# Altium schematic sheet MCU.SchDoc(MCU)
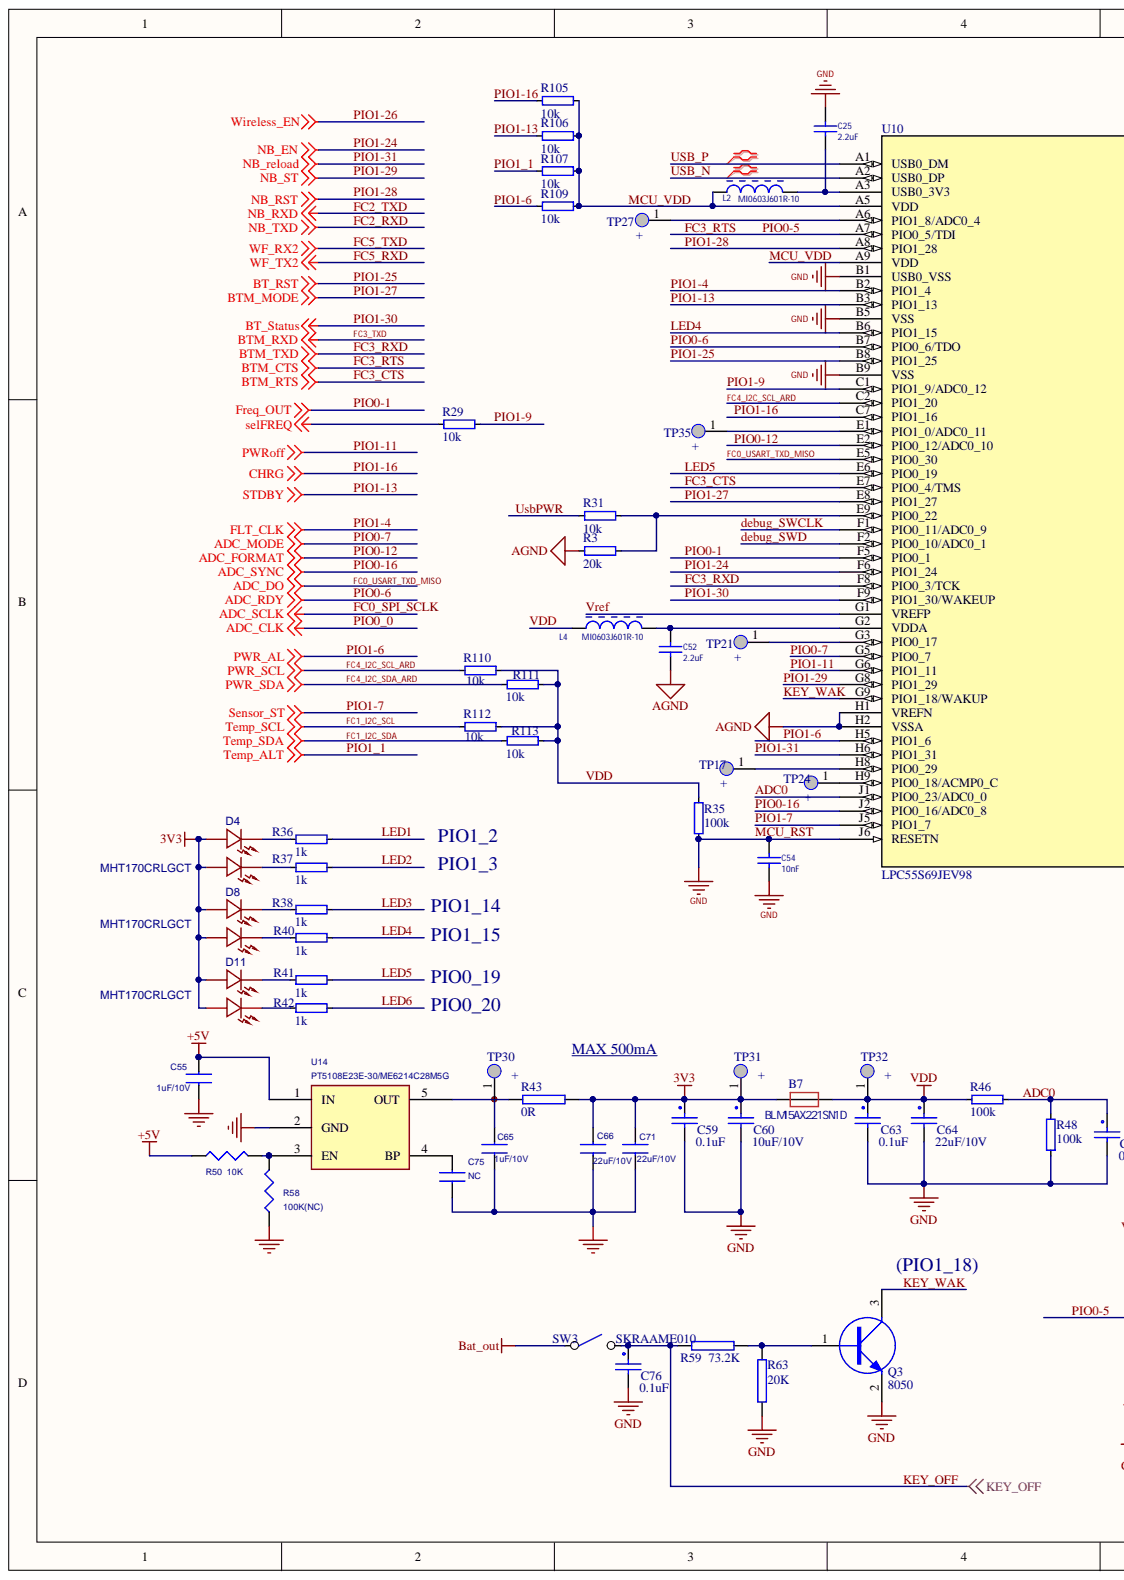
[diagram p0: MCU.SchDoc, left]
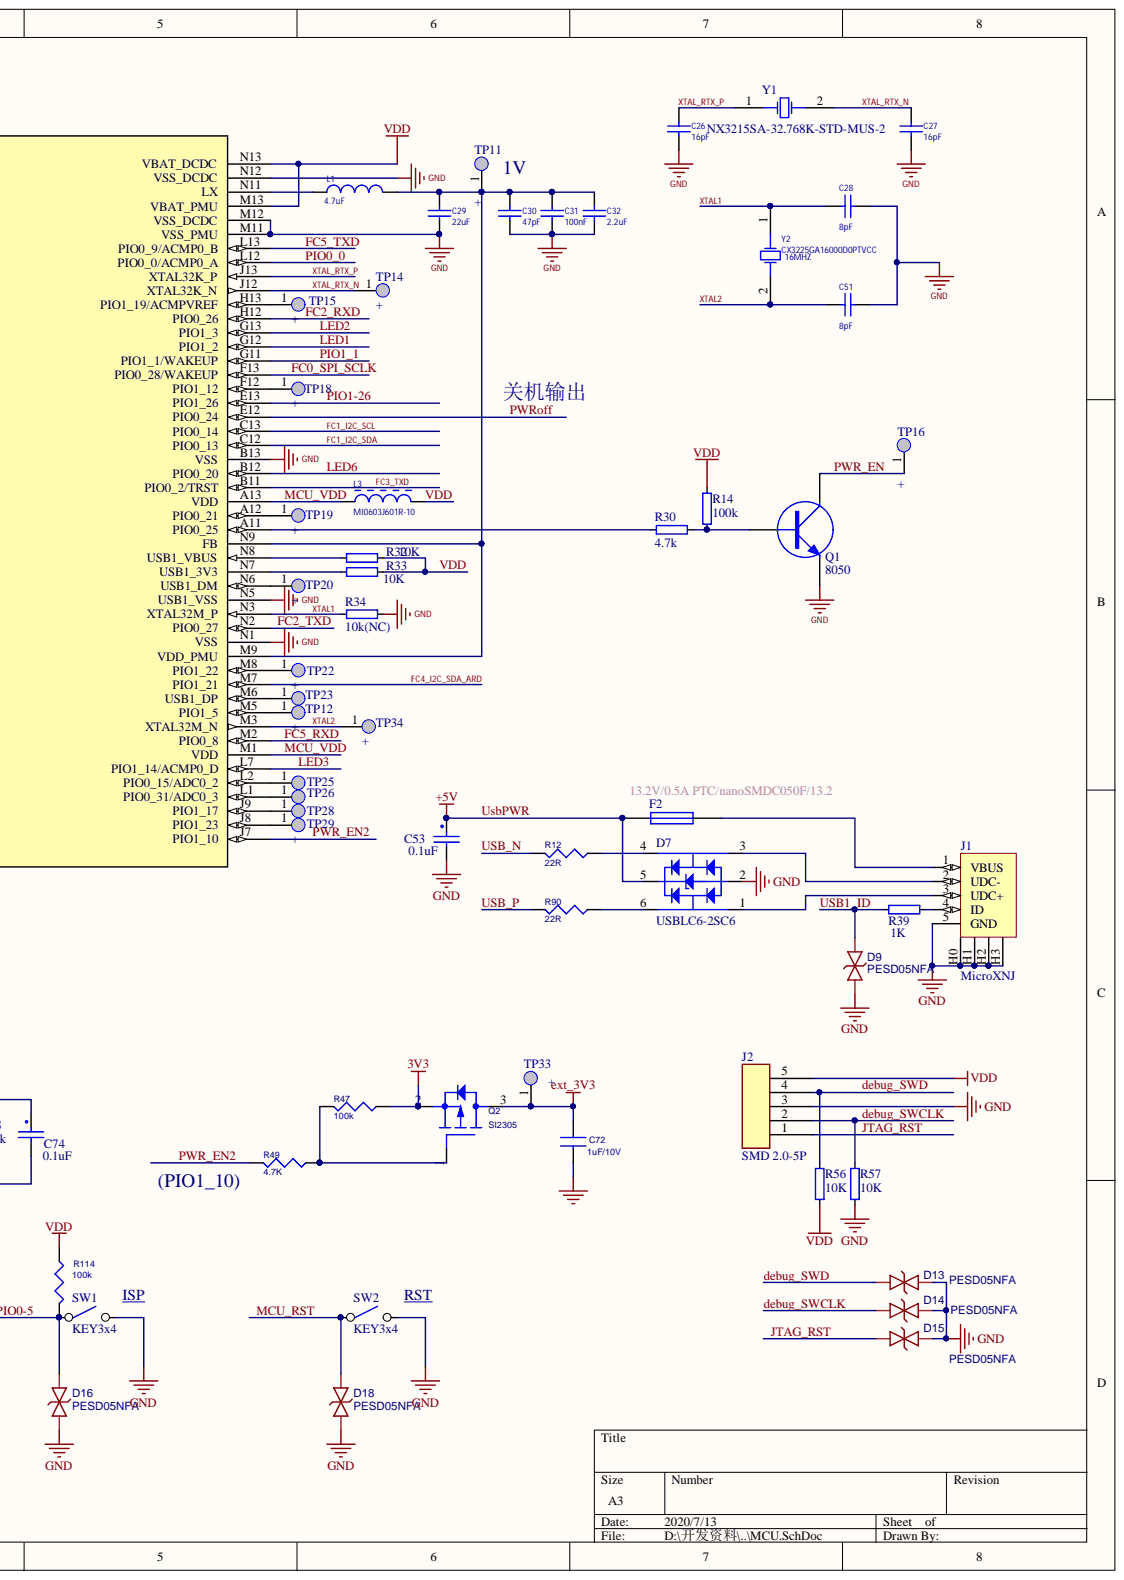
[diagram p1: MCU.SchDoc, right]

## Parts
B7  BLM15AX221SN1D  [B0402]
C25  value 2.2uF  [CAPC0402(1005)60_L]  (hidden comment: CAP 2.2uF 10V 0402(1005))
C26  value 16pF  [CAPC0402(1005)60_L]  (hidden comment: CAP 16pF 25V 0402(1005))
C27  value 16pF  [CAPC0402(1005)60_L]  (hidden comment: CAP 16pF 25V 0402(1005))
C28  value 8pF  [0402_L]  (hidden comment: GRM1555C1E8R0CA01D)
C29  value 22uF  [CAPC0805(2012)145_L]  (hidden comment: CAP 22uF 16V 0805(2012))
C30  value 47pF  [CAPC0603(1608)100_L]  (hidden comment: CAP 47pF 16V 0603(1608))
C31  value 100nF  [CAPC0603(1608)100_L]  (hidden comment: CAP 100nF 25V 0603(1608))
C32  value 2.2uF  [CAPC0402(1005)60_L]  (hidden comment: CAP 2.2uF 10V 0402(1005))
C51  value 8pF  [0402_L]  (hidden comment: GRM1555C1E8R0CA01D)
C52  value 2.2uF  [CAPC0402(1005)60_L]  (hidden comment: CAP 2.2uF 10V 0402(1005))
C53  0.1uF  [0402_C]
C54  value 10nF  [CAPC0603(1608)100_L]  (hidden comment: CAP 100nF 25V 0603(1608))
C55  1uF/10V  [0402_C]
C59  0.1uF  [0402_C]
C60  10uF/10V  [0603_C]
C63  0.1uF  [0402_C]
C64  22uF/10V  [0603_C]
C65  1uF/10V  [0402_C]
C66  22uF/10V  [0603_C]
C71  22uF/10V  [0603_C]
C72  1uF/10V  [0402_C]
C74  0.1uF  [0402_C]
C75  NC  [0402_C]
C76  0.1uF  [0402_C]
D4  MHT170CRLGCT  [LED2_0805]
D7  USBLC6-2SC6  [SOT23-6]
D8  MHT170CRLGCT  [LED2_0805]
D9  PESD05NFA  [D0402-BI]
D11  MHT170CRLGCT  [LED2_0805]
D13  PESD05NFA  [D0402-BI]
D14  PESD05NFA  [D0402-BI]
D15  PESD05NFA  [D0402-BI]
D16  PESD05NFA  [D0402-BI]
D18  PESD05NFA  [D0402-BI]
F2  13.2V/0.5A PTC/nanoSMDC050F/13.2  [F1206]
J1  MicroXNJ  [USB-005H]
J2  SMD 2.0-5P  [P2.0*5]
L1  value 4.7uF  [0805(2012)]  (hidden comment: MLZ2012M4R7HT000)
L2  MI0603J601R-10  [LIRD-MI0603J601R-10_V]
L3  MI0603J601R-10  [LIRD-MI0603J601R-10_V]
L4  MI0603J601R-10  [LIRD-MI0603J601R-10_V]
Q1  8050  [SOT-23(SOT-23-3)]
Q2  SI2305  [SOT23]
Q3  8050  [SOT-23(SOT-23-3)]
R3  20k  [0402]
R12  22R  [0402_R]
R14  100k  [0402]
R29  10k  [0402]
R30  4.7k  [0402]
R31  10k  [0402]
R32  10K  [0402]
R33  10K  [0402]
R34  10k(NC)  [0402]
R35  100k  [0402]
R36  1k  [0402]
R37  1k  [0402]
R38  1k  [0402]
R39  1K  [0402]
R40  1k  [0402]
R41  1k  [0402]
R42  1k  [0402]
R43  0R  [0402_R]
R46  100k  [0402]
R47  100k  [0402_R]
R48  100k  [0402]
R49  4.7K  [0402_R]
R50  10K  [0402_R]
R56  10K  [0402]
R57  10K  [0402]
R58  100K(NC)  [0402_R]
R59  73.2K  [0402_R]
R63  20K  [0402_R]
R90  22R  [0402_R]
R105  10k  [0402]
R106  10k  [0402]
R107  10k  [0402]
R109  10k  [0402]
R110  10k  [0402]
R111  10k  [0402]
R112  10k  [0402]
R113  10k  [0402]
R114  100k  [0402_R]
SW1  KEY3x4  [SMDKEY]
SW2  KEY3x4  [SMDKEY]
SW3  SKRAAME010  [SW-SMD_4P-L6.2-W6.2-P4.00-LS6.8]
TP11  +  [TP]
TP12  +  [TP]
TP14  +  [TP]
TP15  +  [TP]
TP16  +  [TP]
TP17  +  [TP]
TP18  +  [TP]
TP19  +  [TP]
TP20  +  [TP]
TP21  +  [TP]
TP22  +  [TP]
TP23  +  [TP]
TP24  +  [TP]
TP25  +  [TP]
TP26  +  [TP]
TP27  +  [TP]
TP28  +  [TP]
TP29  +  [TP]
TP30  +  [TP]
TP31  +  [TP]
TP32  +  [TP]
TP33  +  [TP]
TP34  +  [TP]
TP35  +  [TP]
U10  LPC55S69JEV98  [VFBGA98]
U14  PT5108E23E-30/ME6214C28M5G  [SOT23-5]
Y1  NX3215SA-32.768K-STD-MUS-2  [2-SMD 3.2x1.5mm]
Y2  CX3225GA16000D0PTVCC  value 16MHZ  [CX3225GA]

## Nets
3V3: B7.1 C59.1 C60.1 C66.1 C71.1 D4.3 D4.4 D8.3 D8.4 D11.3 D11.4 Q2.2 R43.2 R47.2 TP31.1
AGND: C52.1 R3.2 U10.H1(VREFN) U10.H2(VSSA)
BAT_OUT: SW3.1
EXT_3V3: C72.1 Q2.3 TP33.1
SELFREQ: R29.2
ADC0: C74.1 R46.1 R48.1 U10.J1
DEBUG_SWCLK: D14.1 J2.2 R57.1 U10.F1
DEBUG_SWD: D13.1 J2.4 R56.1 U10.F2
FC0_SPI_SCLK: U10.F13
FC0_USART_TXD_MISO: U10.E5
FC1_I2C_SCL: R112.1 U10.C13
FC1_I2C_SDA: R113.1 U10.C12
FC2_RXD: U10.H12
FC2_TXD: U10.N2
FC3_CTS: U10.E7
FC3_RTS: D16.2 R114.1 SW1.1 U10.A7
FC3_RXD: U10.F8
FC3_TXD: U10.B11
FC4_I2C_SCL_ARD: R110.1 U10.C2(PIO1_20)
FC4_I2C_SDA_ARD: R111.1 U10.M7(PIO1_21)
FC5_RXD: U10.M2
FC5_TXD: U10.L13
JTAG_RST: D15.1 J2.1
KEY_OFF: C76.1 R59.2 SW3.2
KEY_WAK: Q3.3 U10.G9(PIO1_18/WAKUP)
LED1: R36.1 U10.G12(PIO1_2)
LED2: R37.1 U10.G13(PIO1_3)
LED3: R38.1 U10.L7(PIO1_14/ACMP0_D)
LED4: R40.1 U10.B6(PIO1_15)
LED5: R41.1 U10.E6
LED6: R42.1 U10.B12
MCU_RST: C25.2 C26.1 C27.1 C28.2 C29.2 C30.1 C31.1 C32.1 C51.2 C53.2 C54.1 C54.2 C55.2 C59.2 C60.2 C63.2 C64.2 C65.2 C66.2 C71.2 C72.2 C74.2 C75.1 C76.2 D7.2 D9.1 D13.2 D14.2 D15.2 D16.1 D18.1 D18.2 J1.5(GND) J1.H0 J1.H1 J1.H2 J1.H3 J2.3 Q1.2 Q3.2 R34.2 R35.1 R48.2 R57.2 R58.1 R63.2 SW1.2 SW2.1 SW2.2 U10.B1(USB0_VSS) U10.B5(VSS) U10.B9(VSS) U10.B13(VSS) U10.J6(RESETN) U10.M11(VSS_PMU) U10.M12(VSS_DCDC) U10.N1(VSS) U10.N5(USB1_VSS) U10.N12(VSS_DCDC) U14.2(GND)
MCU_VDD: L2.1 L3.1 R105.2 R106.2 R107.2 R109.2 U10.A5(VDD) U10.A9(VDD) U10.A13(VDD) U10.M1(VDD)
PIO0-1: U10.F5
PIO0-6: U10.B7
PIO0-7: U10.G5
PIO0-12: U10.E2
PIO0-16: U10.J2
PIO0_0: U10.L12
PIO1-4: U10.B2(PIO1_4)
PIO1-6: R109.1 U10.H5(PIO1_6)
PIO1-7: U10.J5(PIO1_7)
PIO1-9: R29.1 U10.C1(PIO1_9/ADC0_12)
PIO1-11: U10.G6(PIO1_11)
PIO1-13: R106.1 U10.B3(PIO1_13)
PIO1-16: R105.1 U10.C7(PIO1_16)
PIO1-24: U10.F6(PIO1_24)
PIO1-25: U10.B8(PIO1_25)
PIO1-26: U10.E13(PIO1_26)
PIO1-27: U10.E8(PIO1_27)
PIO1-28: U10.A8(PIO1_28)
PIO1-29: U10.G8(PIO1_29)
PIO1-30: U10.F9(PIO1_30/WAKEUP)
PIO1-31: U10.H6(PIO1_31)
PIO1_1: R107.1 U10.G11(PIO1_1/WAKEUP)
PWR_EN: Q1.3 TP16.1
PWR_EN2: R49.1 U10.J7(PIO1_10)
PWROFF: U10.E12
USB1_ID: D9.2 R39.1
USB_N: R12.1 U10.A2(USB0_DP)
USB_P: R90.1 U10.A1(USB0_DM)
USBPWR: C53.1 C55.1 D7.5 F2.2 R31.2 R50.1 U14.1(IN)
VDD: B7.2 C63.1 C64.1 J2.5 L3.2 L4.1 R14.2 R32.2 R33.2 R35.2 R46.2 R56.2 R110.2 R111.2 R112.2 R113.2 R114.2 TP32.1 U10.M13(VBAT_PMU) U10.N13(VBAT_DCDC)
VREF: U10.G1(VREFP)
XTAL1: C28.1 R34.1 U10.N3(XTAL32M_P) Y2.1
XTAL2: C51.1 TP34.1 U10.M3(XTAL32M_N) Y2.2
XTAL_RTX_N: C27.2 TP14.1 U10.J12(XTAL32K_N) Y1.2
XTAL_RTX_P: C26.2 U10.J13(XTAL32K_P) Y1.1
NetC25_1: C25.1 L2.2 U10.A3(USB0_3V3)
NetC29_1: C29.1 C30.2 C31.2 C32.2 L1.2 TP11.1 U10.M9(VDD_PMU) U10.N9(FB)
NetC52_2: C52.2 L4.2 U10.G2(VDDA)
NetC65_1: C65.1 R43.1 TP30.1 U14.5(OUT)
NetC75_2: C75.2 U14.4(BP)
NetD4_1: D4.1 R36.2
NetD4_2: D4.2 R37.2
NetD7_1: D7.1 J1.3(UDC+)
NetD7_3: D7.3 J1.2(UDC-)
NetD7_4: D7.4 R12.2
NetD7_6: D7.6 R90.2
NetD8_1: D8.1 R38.2
NetD8_2: D8.2 R40.2
NetD11_1: D11.1 R41.2
NetD11_2: D11.2 R42.2
NetF2_1: F2.1 J1.1(VBUS)
NetJ1_4: J1.4(ID) R39.2
NetL1_1: L1.1 U10.N11(LX)
NetQ1_1: Q1.1 R14.1 R30.1
NetQ2_1: Q2.1 R47.1 R49.2
NetQ3_1: Q3.1 R59.1 R63.1
NetR3_1: R3.1 R31.1 U10.E9
NetR30_2: R30.2 U10.A11
NetR32_1: R32.1 U10.N8(USB1_VBUS)
NetR33_1: R33.1 U10.N7(USB1_3V3)
NetR50_2: R50.2 R58.2 U14.3(EN)
NetTP12_1: TP12.1 U10.M5(PIO1_5)
NetTP15_1: TP15.1 U10.H13(PIO1_19/ACMPVREF)
NetTP17_1: TP17.1 U10.H8
NetTP18_1: TP18.1 U10.F12(PIO1_12)
NetTP19_1: TP19.1 U10.A12
NetTP20_1: TP20.1 U10.N6(USB1_DM)
NetTP21_1: TP21.1 U10.G3
NetTP22_1: TP22.1 U10.M8(PIO1_22)
NetTP23_1: TP23.1 U10.M6(USB1_DP)
NetTP24_1: TP24.1 U10.H9
NetTP25_1: TP25.1 U10.L2
NetTP26_1: TP26.1 U10.L1
NetTP27_1: TP27.1 U10.A6(PIO1_8/ADC0_4)
NetTP28_1: TP28.1 U10.J9(PIO1_17)
NetTP29_1: TP29.1 U10.J8(PIO1_23)
NetTP35_1: TP35.1 U10.E1(PIO1_0/ADC0_11)

## Library data of the parts
B7 = Bead: Component Kind: Standard; Library Name: RP3288BV03_20180126-.SCHLIB
C25 C32 C52 = CMP-1034-02127-1: CAP 2.2uF 10V ±10% 0402 (1005 Metric) Thickness 0.6mm SMD; Case-EIA: 0402; Case-Metric: 1005; Tolerance: Â±10%; Component Kind: Standard; Library Name: Altium Content Vault; Max Thickness: 0.6 mm; Rated Voltage: 10 V; Technology: SMT
C26 C27 = CMP-1034-00833-1: CAP 16pF 25V ±0.5pF 0402 (1005 Metric) Thickness 0.6mm SMD; Case-EIA: 0402; Case-Metric: 1005; Tolerance: Â±0.5pF; Component Kind: Standard; Library Name: Altium Content Vault; Max Thickness: 0.6 mm; Rated Voltage: 25 V; Technology: SMT
C28 C51 = Capacitor: CAP CER 8PF 25V C0G/NP0 0402; Manufacturer: Murata Electronics North America; Component Kind: Standard; Manufacturer part #: GRM1555C1E8R0CA01D; Signal Integrity: Cap; Simulation: CAP; Library Name: CODE Miscellaneous Devices.IntLib
C29 = CMP-1036-04946-1: CAP 22uF 16V ±20% 0805 (2012 Metric) Thickness 1.45mm SMD; Case-EIA: 0805; Case-Metric: 2012; Tolerance: Â±20%; Component Kind: Standard; Library Name: Altium Content Vault; Max Thickness: 1.45 mm; Rated Voltage: 16 V; Technology: SMT
C30 = CMP-1035-01048-1: CAP 47pF 16V ±5pF 0603 (1608 Metric) Thickness 1mm SMD; Case-EIA: 0603; Case-Metric: 1608; Component Kind: Standard; Library Name: Altium Content Vault; Max Thickness: 1 mm; Rated Voltage: 16 V; Technology: SMT; Tolerance: ±5pF
C31 C54 = CMP-1035-02589-1: CAP 100nF 25V ±20% 0603 (1608 Metric) Thickness 1mm SMD; Case-EIA: 0603; Case-Metric: 1608; Tolerance: Â±20%; Component Kind: Standard; Library Name: Altium Content Vault; Max Thickness: 1 mm; Rated Voltage: 25 V; Technology: SMT
C53 C59 C63 C74 C76 = CAP_100N_25V_0402_10%_X5R: MANUFACTURER: TAIYO YUDEN; PART: TMK105BJ104KV-F; Component Kind: Standard; Description: 0.1uF; FARNELL: 1611916; Library Name: Arbury_C.SchLib
C55 C65 C66 C71 = CAP NP_Dup4: RoHS CHIP CAP 4.7uF +10/-10% X5R; Component Kind: Standard
C60 C64 = CAP_100N_25V_0402_10%_X5R: 100nF; MANUFACTURER: TAIYO YUDEN; PART: TMK105BJ104KV-F; Component Kind: Standard; FARNELL: 1611916; Library Name: Arbury_C.SchLib
C72 = CAP NP_Dup2: CAP,MLCC,100nF,+/-10%,X7R,6.3V,SMD0402; Component Kind: Standard
C75 = CAP NP_Dup4: RoHS CHIP CAP 0.1uF +10/-10% X7R; Component Kind: Standard
D4 D8 D11 = MHT170CRLGCT: BOM_Manufacturer: MEIHUA; Contributor: LCSC; BOM_Manufacturer Part: MHT170CRLGCT; BOM_Supplier: LCSC; BOM_Supplier Part: C391863; Component Kind: Standard; spicePre: L
D7 = D_ESD_PRTR5V0U2F_115: Ultra low capacitance double rail-to-rail ESD protection; MANUFACTURER: NXP; Component Kind: Standard; FARNELL: 2459738; PART: PRTR5V0U2F_115; Library Name: Arbury_SCH.SchLib
D9 D13-D16 D18 = PESD05NFA: BOM_Manufacturer: PTTC(Polytronics Tech); Contributor: LCSC; BOM_Manufacturer Part: PESD05NFA; BOM_Supplier: LCSC; BOM_Supplier Part: C438894; Component Kind: Standard; spicePre: D; spiceSymbolName: PESD05NFA
F2 = FUSE1: Fuse; Component Kind: Standard
J1 = CON_USB_MICRO_AB_MOLEX: USB Micro-AB socket Molex 47589-0001; RS: 702-5488; Component Kind: Standard; Farnell: 156-8023; MANUFACTURER: Molex; PART: 47589-0001; Library Name: Arbury_CON.SchLib
J2 = CON5: Component Kind: Standard; Library Name: 下位机控制板.SCHLIB
L1 = MLZ2012M4R7HT000: FIXED IND 4.7UH 400MA 340 MOHM; Manufacturer: TDK Corporation; Component Kind: Standard; Creation date: 06-Nov-2018; Library Name: Fixed.IntLib; Manufacturer part #: MLZ2012M4R7HT000; Created by: eteofilo
L2-L4 = CMP-2000-06700-1: Ferrite Bead, 600 Ohm, 1 A, -40 to 125 degC, 2-Pin SMD, RoHS, Tape and Reel; Manufacturer: Laird; Catalog Drawings: (HI,HZ,LI,MI)0603Series_0.95mmMax.Height; Current Rating (Max): 1A; DC Resistance (DCR) (Max): 200mOhm; Filter Type: PowerLine; Impedance @ Frequency: 600Ohm@100MHz; Kits: 240-2227-ND-KITEMIFERRITEBEADCHIPSMD; Number of Lines: 1; Online Catalog: MISeries; Other Names: 240-2371-1; Category: Filters; Component Kind: Standard; Datasheet URL: http://cdn.lairdtech.com/home/brandworld/files/MI0603J601R-10.pdf; Datasheet Version: Rev. C, 08/2013; Family: FerriteBeadsandChips; Height (Max): 0.037"(0.95mm); Library Name: Altium Content Vault; Manufacturer URL: https://www.lairdtech.com/; Mounting Technology: SurfaceMount; Mounting Type: SurfaceMount; Operating Temperature: -40°C~125°C; Package / Case: 0603(1608Metric); Package Description: 2-Pin Surface Mount Device, Body 1.6 x 0.8 mm; Package Reference: MI00603J601R-10; Packaging: CutTape(CT); Ratings: -; Series: MI; Size / Dimension: 0.063"Lx0.031"W(1.60mmx0.80mm); Standard Package: 1
Q1 Q3 = NPN: NPN Transistor; Component Kind: Standard
Q2 = FET P MOS: Component Kind: Standard
R3 R14 R29-R42 R46 R48 R56 R57 R105-R107 R109-R113 = R0402-12k: Resistor, ?%, 0.063W; Artikkelnr Noca: 27049099; Database Table Name: Resistors$; Note: Chip Res 1%; Value: 12k; Component Kind: Standard; LatestRevisionDate: 2009-11-23; Library Name: Nordic Passive DB.DbLib; Part Number: R0402-12k; Pin Count: 2; Published: 2009-05-08; Supplier: Farnell; Supplier part number: 173-8865; Publisher: Nordic Semiconductor
R12 R47 R49 R90 R114 = RESISTOR_1: RES,thick film,47KOhm,+/-5%,1/16W,SMD0402; Component Kind: Standard
R43 R59 R63 = RES2: Component Kind: Standard
R50 R58 = RESISTOR_Dup2: ROHS CHIP RES 10K 1/16W 5%; Component Kind: Standard
SW1-SW3 = SW_SPST_6MM: SPST SWITCH 6MM; MANUFACTURER: TE CONNECTIVITY / ALCOSWITCH; PART: FSM4JSMA; Component Kind: Standard; FARNELL: 3801305; Library Name: Arbury_SCH.SchLib
TP11 TP12 TP14-TP35 = TP: Component Kind: Standard; Library Name: mysch.SchLib
U10 = LPC55S69JEV98: Imported; Built_By: EMA_Jessica; C: Copyright (C) 2018 Accelerated Designs. All rights reserved; Vendor: NXP; Component Kind: Standard; Manufacturer_Part_Number: LPC55S69JEV98; RefDes: RefDes; Type: Type; Value: Value; Copyright: Copyright (C) 2018 Accelerated Designs. All rights reserved; Library Name: 无线振动传感器.SCHLIB
U14 = LDO-PT5108/RT9013: Component Kind: Standard
Y1 = NX3215SA-32.768K-STD-MUS-2: CRYSTAL 32.7680KHZ 12.5PF SMD; Manufacturer: NDK; Value: 32.768KHz; Component Kind: Standard; Creation Date: 05-Jun-2018; Library Name: Crystals.IntLib; Manufacturer Part #: NX3215SA-32.768K-STD-MUS-2; Created by: eteofilo
Y2 = CX3225GA16000D0PTVCC: CRYSTAL 16.0000MHZ 8PF SMD; Manufacturer: Kyocera International Inc. Electronic Components; Component Kind: Standard; Creation date: 25-May-2018; Library Name: Crystals.IntLib; Manufacturer part #: CX3225GA16000D0PTVCC; Created by: eteofilo
